# Altium schematic sheet Quadcopter.SchDoc(Quadcopter)
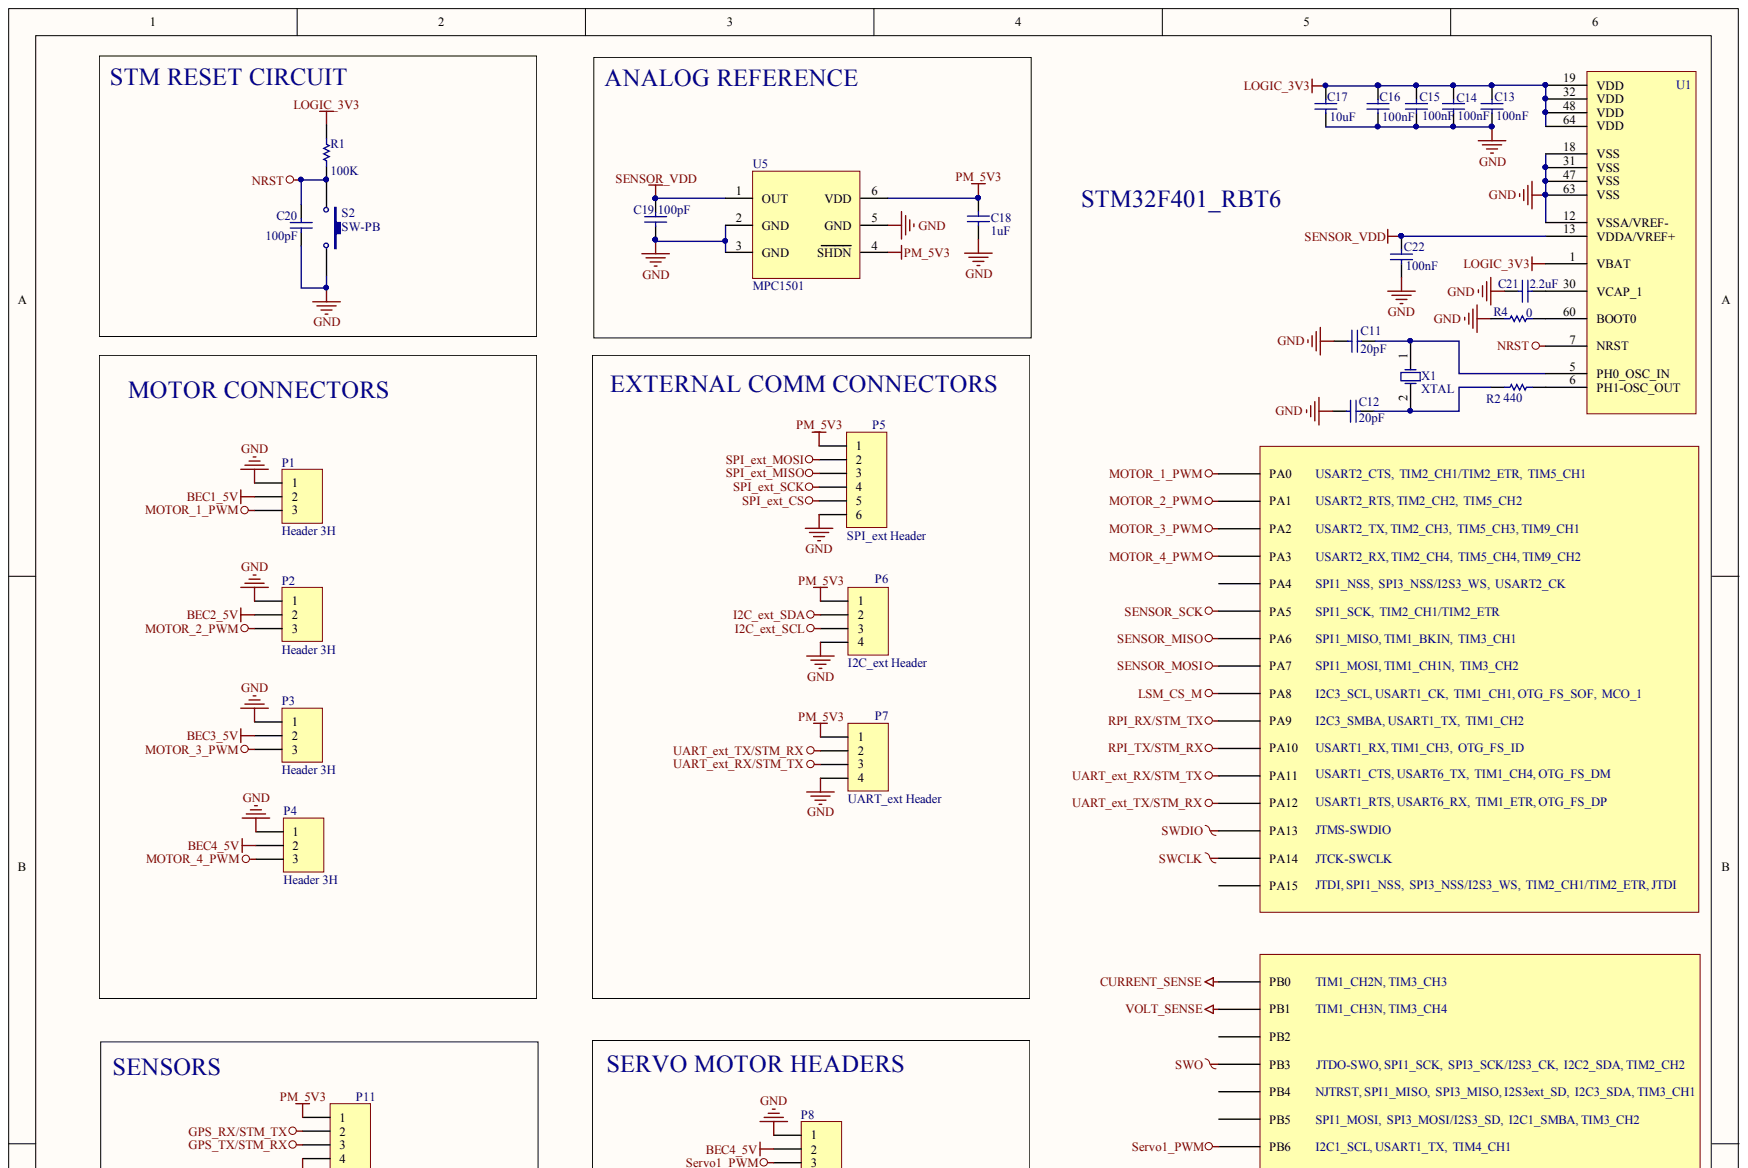
[diagram p0: Quadcopter.SchDoc, top]
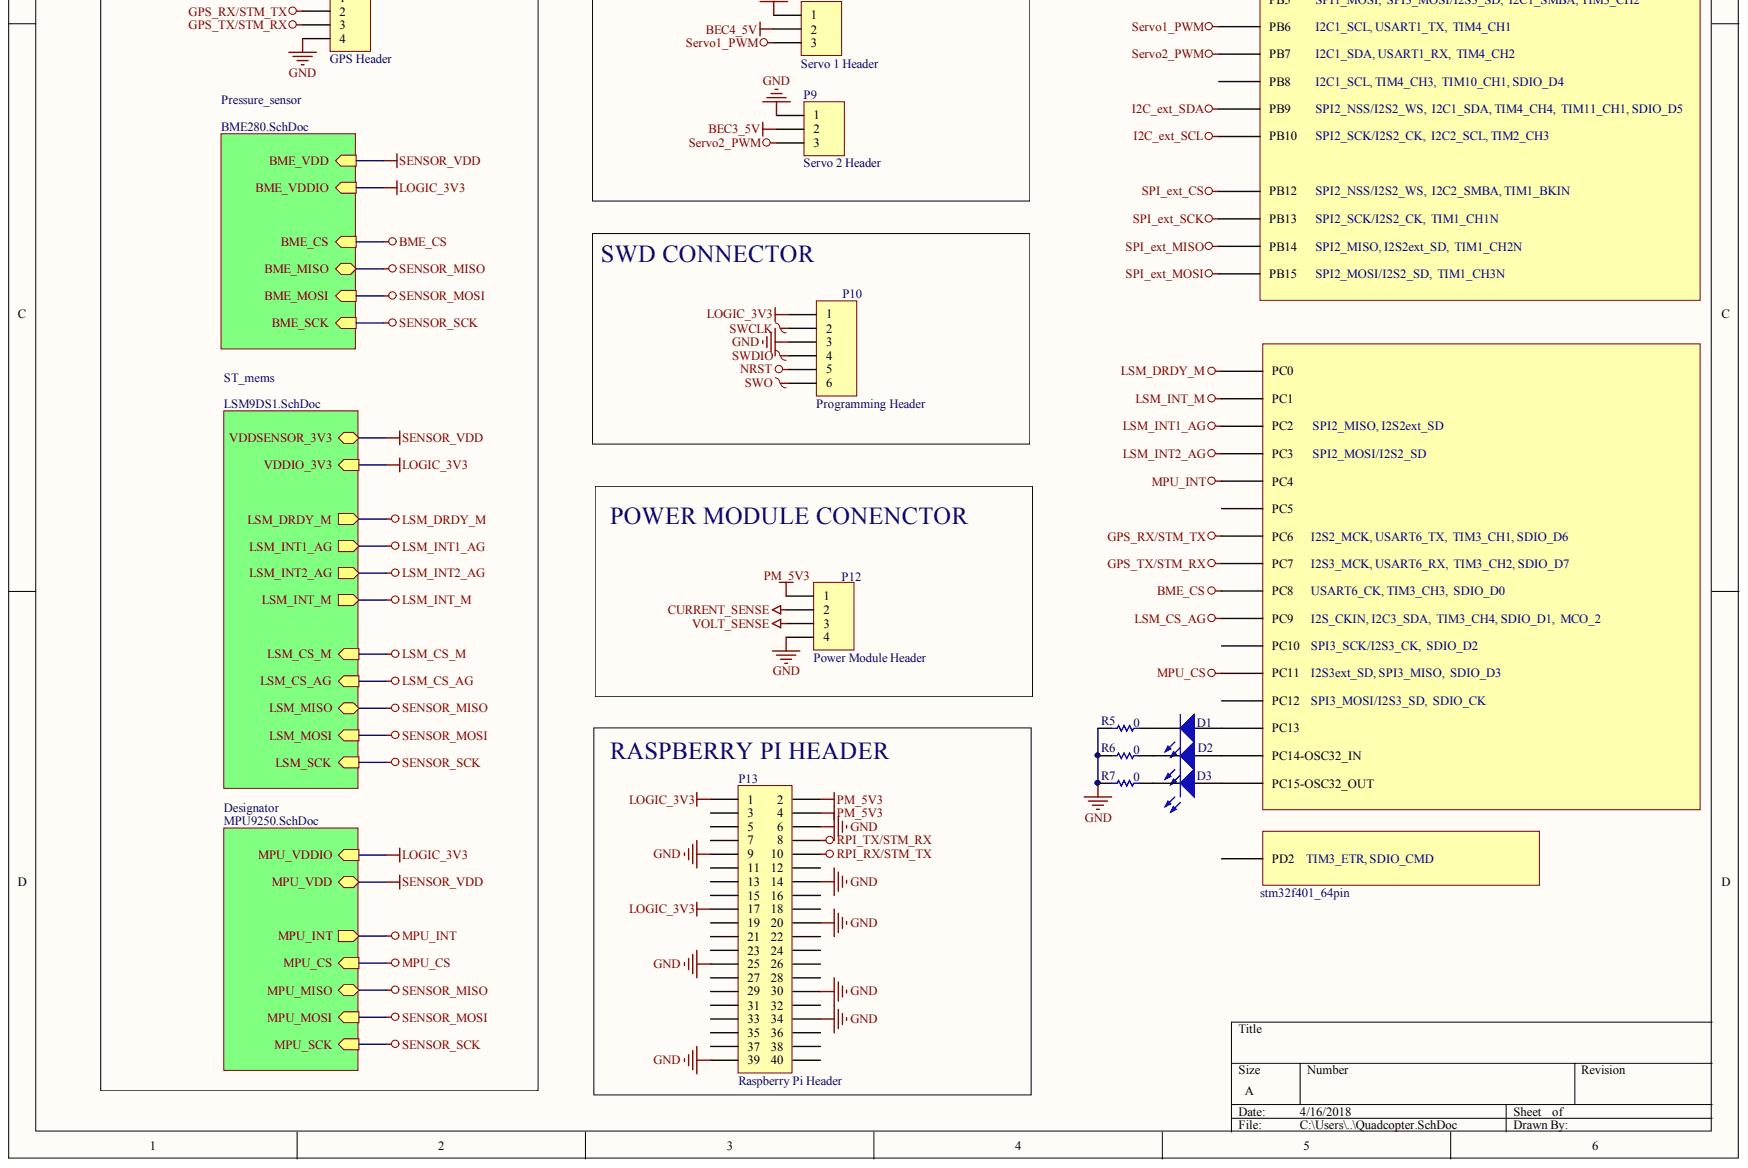
[diagram p1: Quadcopter.SchDoc, bottom]

## Parts
C11  value 20pF  [0402]  (hidden comment: Cap Semi)
C12  value 20pF  [0402]  (hidden comment: Cap Semi)
C13  value 100nF  [0402]  (hidden comment: Cap Semi)
C14  value 100nF  [0402]  (hidden comment: Cap Semi)
C15  value 100nF  [0402]  (hidden comment: Cap Semi)
C16  value 100nF  [0402]  (hidden comment: Cap Semi)
C17  value 10uF  [0402]  (hidden comment: Cap Semi)
C18  value 1uF  [0402]  (hidden comment: Cap Semi)
C19  value 100pF  [0402]  (hidden comment: Cap Semi)
C20  value 100pF  [0402]  (hidden comment: Cap Semi)
C21  value 2.2uF  [0402]  (hidden comment: Cap Semi)
C22  value 100nF  [0402]  (hidden comment: Cap Semi)
D1  LED2  [0402]  (value not drawn)
D2  LED2  [0402]  (value not drawn)
D3  LED2  [0402]  (value not drawn)
P1  Header 3H  [HDR1X3H]
P2  Header 3H  [HDR1X3H]
P3  Header 3H  [HDR1X3H]
P4  Header 3H  [HDR1X3H]
P5  SPI_ext Header  [HDR1X6]
P6  I2C_ext Header  [HDR1X4]
P7  UART_ext Header  [HDR1X4]
P8  Servo 1 Header  [HDR1X3H]
P9  Servo 2 Header  [HDR1X3H]
P10  Programming Header  [HDR1X6]
P11  GPS Header  [HDR1X4]
P12  Power Module Header  [HDR1X4H]
P13  Raspberry Pi Header  [HDR2X20]
R1  value 100K  [0402]  (hidden comment: Res1)
R2  value 440  [0402]  (hidden comment: Res1)
R4  value 0  [0402]  (hidden comment: Res1)
R5  value 0  [0402]  (hidden comment: Res1)
R6  value 0  [0402]  (hidden comment: Res1)
R7  value 0  [0402]  (hidden comment: Res1)
S2  SW-PB  [PUSH_BUTTON]
U1  stm32f401_64pin  [LQFP64]
U5  MPC1501  [SOT23-6_N]
X1  XTAL  [SM_Crystal_HC49US]

## Nets
BEC1_5V: P1.2
BEC2_5V: P2.2
BEC3_5V: P3.2 P9.2
BEC4_5V: P4.2 P8.2
BME_CS: U1.39(PC8)
CURRENT_SENSE: P12.2 U1.26(PB0)
GND: C11.2 C12.2 C13.2 C14.2 C15.2 C16.2 C17.2 C18.2 C19.2 C20.2 C21.1 C22.2 P1.1 P2.1 P3.1 P4.1 P5.6 P6.4 P7.4 P8.1 P9.1 P10.3 P11.4 P12.4 P13.6 P13.9 P13.14 P13.20 P13.25 P13.30 P13.34 P13.39 R4.2 R5.2 R6.2 R7.2 S2.2 U1.12 U1.18 U1.31 U1.47 U1.63 U5.2(GND) U5.3(GND) U5.5(GND)
GPS_RX/STM_TX: P11.2 U1.37(PC6)
GPS_TX/STM_RX: P11.3 U1.38(PC7)
I2C_EXT_SCL: P6.3 U1.29(PB10)
I2C_EXT_SDA: P6.2 U1.62(PB9)
LOGIC_3V3: C13.1 C14.1 C15.1 C16.1 C17.1 P10.1 P13.1 P13.17 R1.2 U1.1(LOGIC_3V3) U1.19 U1.32 U1.48 U1.64
LSM_CS_AG: U1.40(PC9)
LSM_CS_M: U1.41(PA8)
LSM_DRDY_M: U1.8(PC0)
LSM_INT1_AG: U1.10(PC2)
LSM_INT2_AG: U1.11(PC3)
LSM_INT_M: U1.9(PC1)
MOTOR_1_PWM: P1.3 U1.14(PA0)
MOTOR_2_PWM: P2.3 U1.15(PA1)
MOTOR_3_PWM: P3.3 U1.16(PA2)
MOTOR_4_PWM: P4.3 U1.17(PA3)
MPU_CS: U1.52(PC11)
MPU_INT: U1.24(PC4)
NRST: C20.1 P10.5 R1.1 S2.1 U1.7(NRST)
PM_5V3: C18.1 P5.1 P6.1 P7.1 P11.1 P12.1 P13.2 P13.4 U5.4(SHDN) U5.6(VDD)
RPI_RX/STM_TX: P13.10 U1.42(PA9)
RPI_TX/STM_RX: P13.8 U1.43(PA10)
SENSOR_MISO: U1.22(PA6)
SENSOR_MOSI: U1.23(PA7)
SENSOR_SCK: U1.21(PA5)
SENSOR_VDD: C19.1 C22.1 U1.13 U5.1(OUT)
SERVO1_PWM: P8.3 U1.58(PB6)
SERVO2_PWM: P9.3 U1.59(PB7)
SPI_EXT_CS: P5.5 U1.33(PB12)
SPI_EXT_MISO: P5.3 U1.35(PB14)
SPI_EXT_MOSI: P5.2 U1.36(PB15)
SPI_EXT_SCK: P5.4 U1.34(PB13)
SWCLK: P10.2 U1.49(PA14)
SWDIO: P10.4 U1.46(PA13)
SWO: P10.6 U1.55(PB3)
UART_EXT_RX/STM_TX: P7.3 U1.44(PA11)
UART_EXT_TX/STM_RX: P7.2 U1.45(PA12)
VOLT_SENSE: P12.3 U1.27(PB1)
NetC11_1: C11.1 U1.5 X1.1
NetC12_1: C12.1 R2.2 X1.2
NetC21_2: C21.2 U1.30
NetD1_1: D1.1 U1.2(PC13)
NetD1_2: D1.2 R5.1
NetD2_1: D2.1 U1.3(PC14-OSC32_IN)
NetD2_2: D2.2 R6.1
NetD3_1: D3.1 U1.4(PC15-OSC32_OUT)
NetD3_2: D3.2 R7.1
NetP13_3: P13.3
NetP13_5: P13.5
NetP13_7: P13.7
NetP13_11: P13.11
NetP13_12: P13.12
NetP13_13: P13.13
NetP13_15: P13.15
NetP13_16: P13.16
NetP13_18: P13.18
NetP13_19: P13.19
NetP13_21: P13.21
NetP13_22: P13.22
NetP13_23: P13.23
NetP13_24: P13.24
NetP13_26: P13.26
NetP13_27: P13.27
NetP13_28: P13.28
NetP13_29: P13.29
NetP13_31: P13.31
NetP13_32: P13.32
NetP13_33: P13.33
NetP13_35: P13.35
NetP13_36: P13.36
NetP13_37: P13.37
NetP13_38: P13.38
NetP13_40: P13.40
NetR2_1: R2.1 U1.6
NetR4_1: R4.1 U1.60
NetU1_20: U1.20(PA4)
NetU1_25: U1.25(PC5)
NetU1_28: U1.28(PB2)
NetU1_50: U1.50(PA15)
NetU1_51: U1.51(PC10)
NetU1_53: U1.53(PC12)
NetU1_54: U1.54(PD2)
NetU1_56: U1.56(PB4)
NetU1_57: U1.57(PB5)
NetU1_61: U1.61(PB8)

## Library data of the parts
C11-C22 = Cap Semi: Capacitor (Semiconductor SIM Model); Component Kind: Standard; LatestRevisionDate: 29-May-2009; LatestRevisionNote: IPC-7351 Footprint Added.; Library Name: Miscellaneous Devices.IntLib; PackageDescription: Chip Capacitor; PackageReference: C1206; Published: 8-Jun-2000; Signal Integrity: Cap Semi; Simulation: CAP; Publisher: Altium Limited
D1-D3 = LED2: Typical RED, GREEN, YELLOW, AMBER GaAs LED; Component Kind: Standard; LatestRevisionDate: 17-Jul-2002; LatestRevisionNote: Re-released for DXP Platform.; Library Name: Miscellaneous Devices.IntLib; PackageDescription: SM LED; 2 Flat Leads; PackageReference: 3.2X1.6X1.1; PackageVersion: Feb-2002; Published: 8-Jun-2000; Simulation: LED2; Publisher: Altium Limited
P1-P4 P8 P9 = Header 3H: Header, 3-Pin, Right Angle; Component Kind: Standard; LatestRevisionDate: 17-Jul-2002; LatestRevisionNote: Re-released for DXP Platform.; Library Name: Miscellaneous Connectors.IntLib; Signal Integrity: Connector; Publisher: Altium Limited
P5 P10 = Header 6: Header, 6-Pin; Component Kind: Standard; LatestRevisionDate: 17-Jul-2002; LatestRevisionNote: Re-released for DXP Platform.; Library Name: Miscellaneous Connectors.IntLib; Signal Integrity: Connector; Publisher: Altium Limited
P6 P7 P11 = Header 4: Header, 4-Pin; Component Kind: Standard; LatestRevisionDate: 17-Jul-2002; LatestRevisionNote: Re-released for DXP Platform.; Library Name: Miscellaneous Connectors.IntLib; Signal Integrity: Connector; Publisher: Altium Limited
P12 = Header 4H: Header, 4-Pin, Right Angle; Component Kind: Standard; LatestRevisionDate: 17-Jul-2002; LatestRevisionNote: Re-released for DXP Platform.; Library Name: Miscellaneous Connectors.IntLib; Signal Integrity: Connector; Publisher: Altium Limited
P13 = Header 20X2: Header, 20-Pin, Dual row; Component Kind: Standard; LatestRevisionDate: 17-Jul-2002; LatestRevisionNote: Re-released for DXP Platform.; Library Name: Miscellaneous Connectors.IntLib; Signal Integrity: Connector; Publisher: Altium Limited
R1 R2 R4-R7 = Res1: Resistor; Component Kind: Standard; LatestRevisionDate: 17-Jul-2002; LatestRevisionNote: Re-released for DXP Platform.; Library Name: Miscellaneous Devices.IntLib; PackageDescription: Axial Device, Thru-Hole; 2 Leads; 0.3 in Pin Spacing; PackageReference: AXIAL-0.3; Published: 8-Jun-2000; Signal Integrity: Res; Simulation: RESISTOR; Publisher: Altium Limited
S2 = SW-PB: Switch; Component Kind: Standard; LatestRevisionDate: 17-Jul-2002; LatestRevisionNote: Re-released for DXP Platform.; Library Name: Miscellaneous Devices.IntLib; PackageDescription: Switch; 2 Leads; PackageReference: SPST-2; Published: 24-Mar-1999; Publisher: Altium Limited
X1 = XTAL: Crystal Oscillator; Component Kind: Standard; LatestRevisionDate: 17-Jul-2002; LatestRevisionNote: Re-released for DXP Platform.; Library Name: Miscellaneous Devices.IntLib; PackageDescription: Crystal, Thru-Hole; 2 Leads; Body 3.1 x 8.2 mm (Dia.xH); PackageReference: R38; Published: 8-Jun-2000; Simulation: XTAL; Publisher: Altium Limited
U1 = stm32f401_64pin: Component Kind: Standard; Library Name: STM32.SchLib
U5 = MPC1501: Component Kind: Standard; Library Name: MPC1501.SchLib
